# Altium schematic sheet Microphone.SchDoc
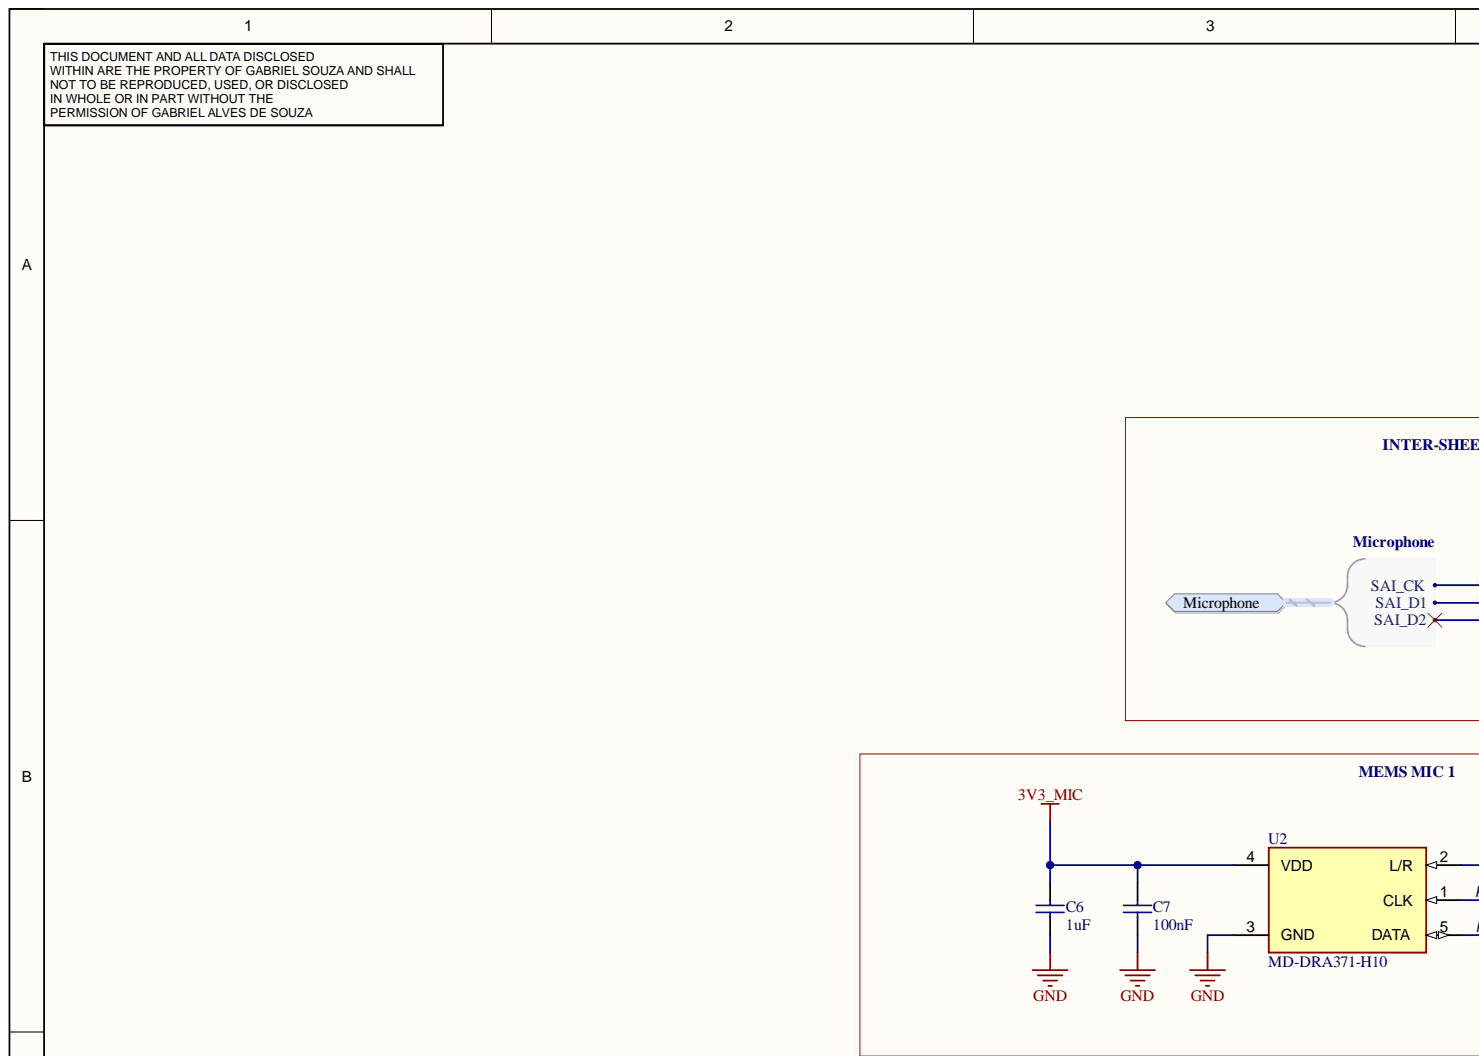
[diagram p0: Microphone.SchDoc, top left]
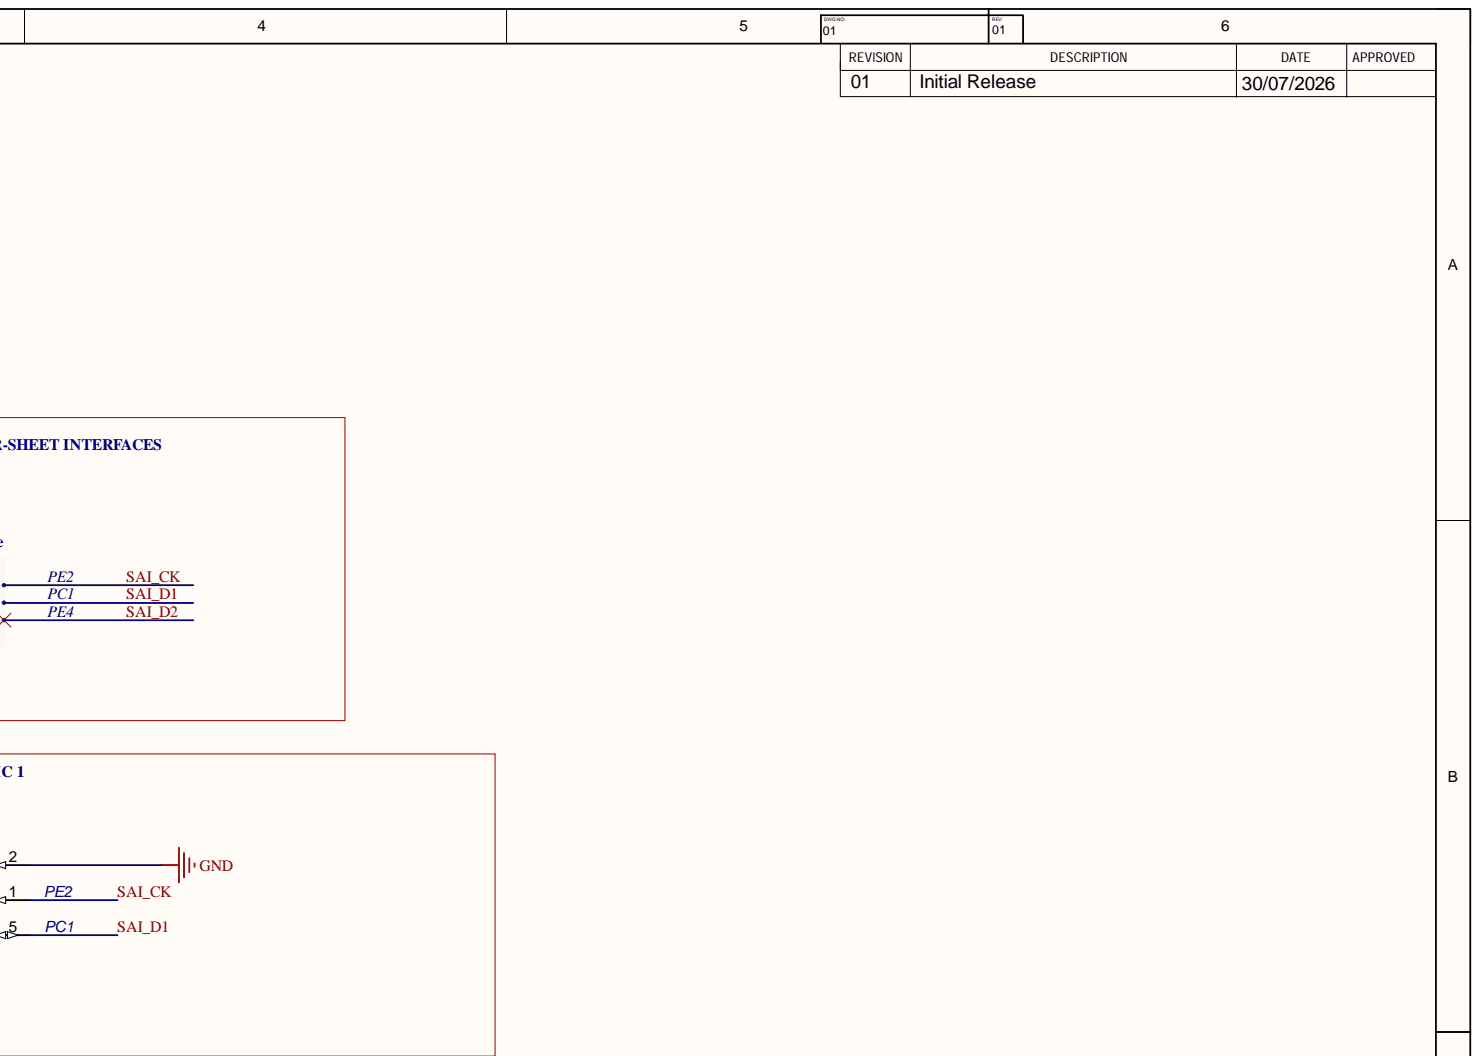
[diagram p1: Microphone.SchDoc, top right]
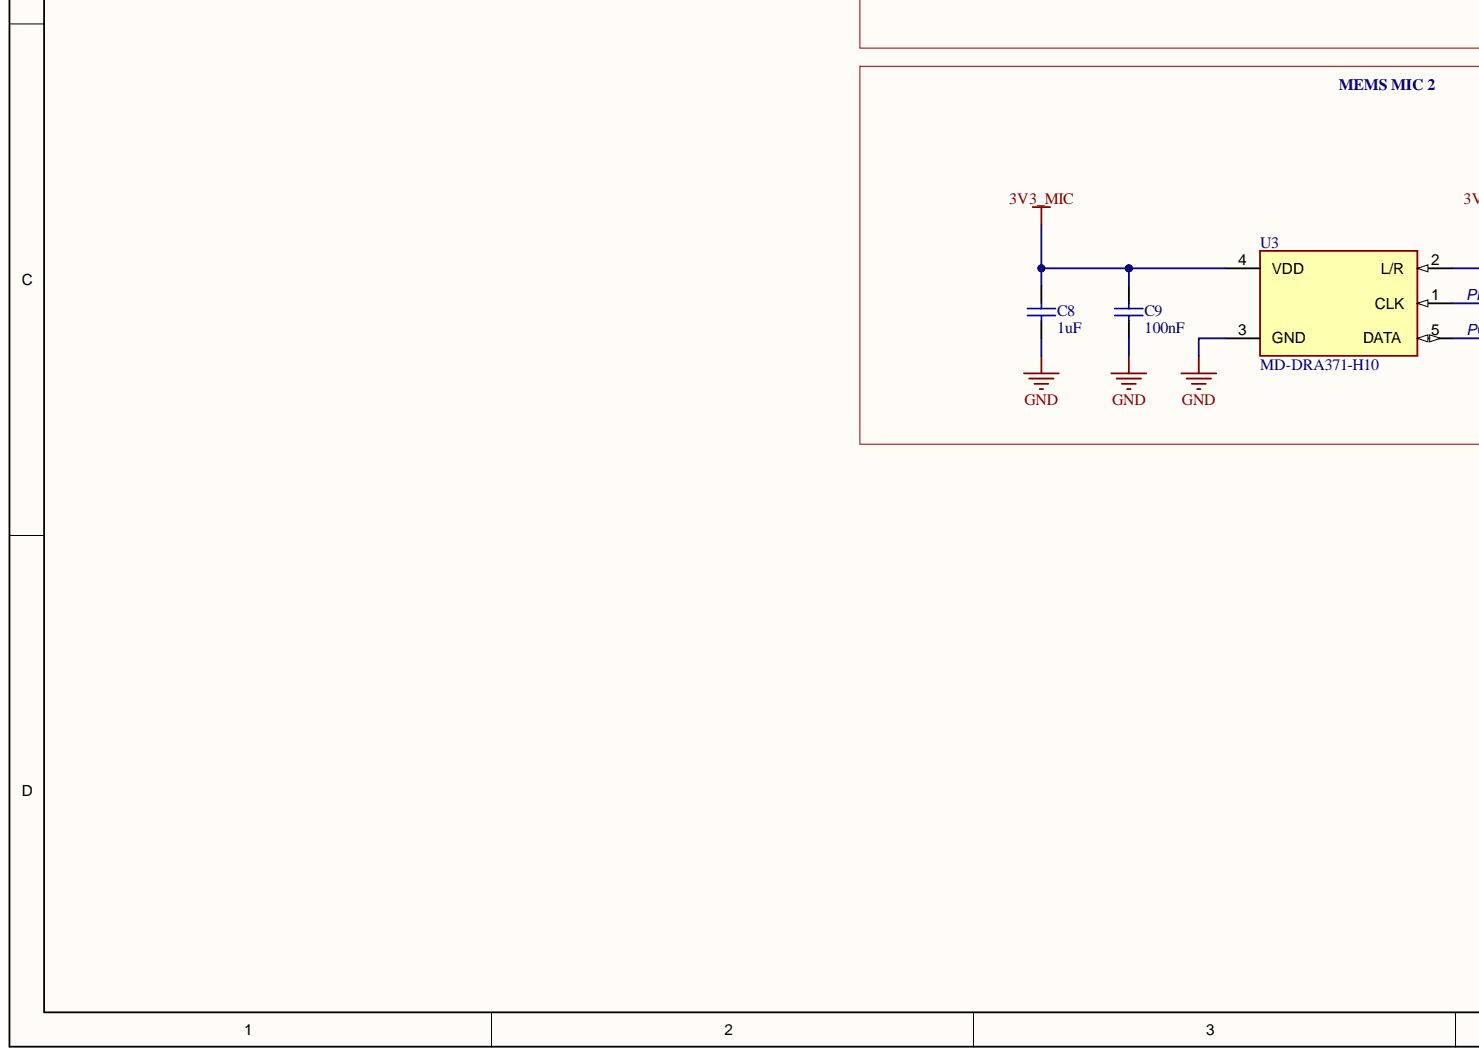
[diagram p2: Microphone.SchDoc, bottom left]
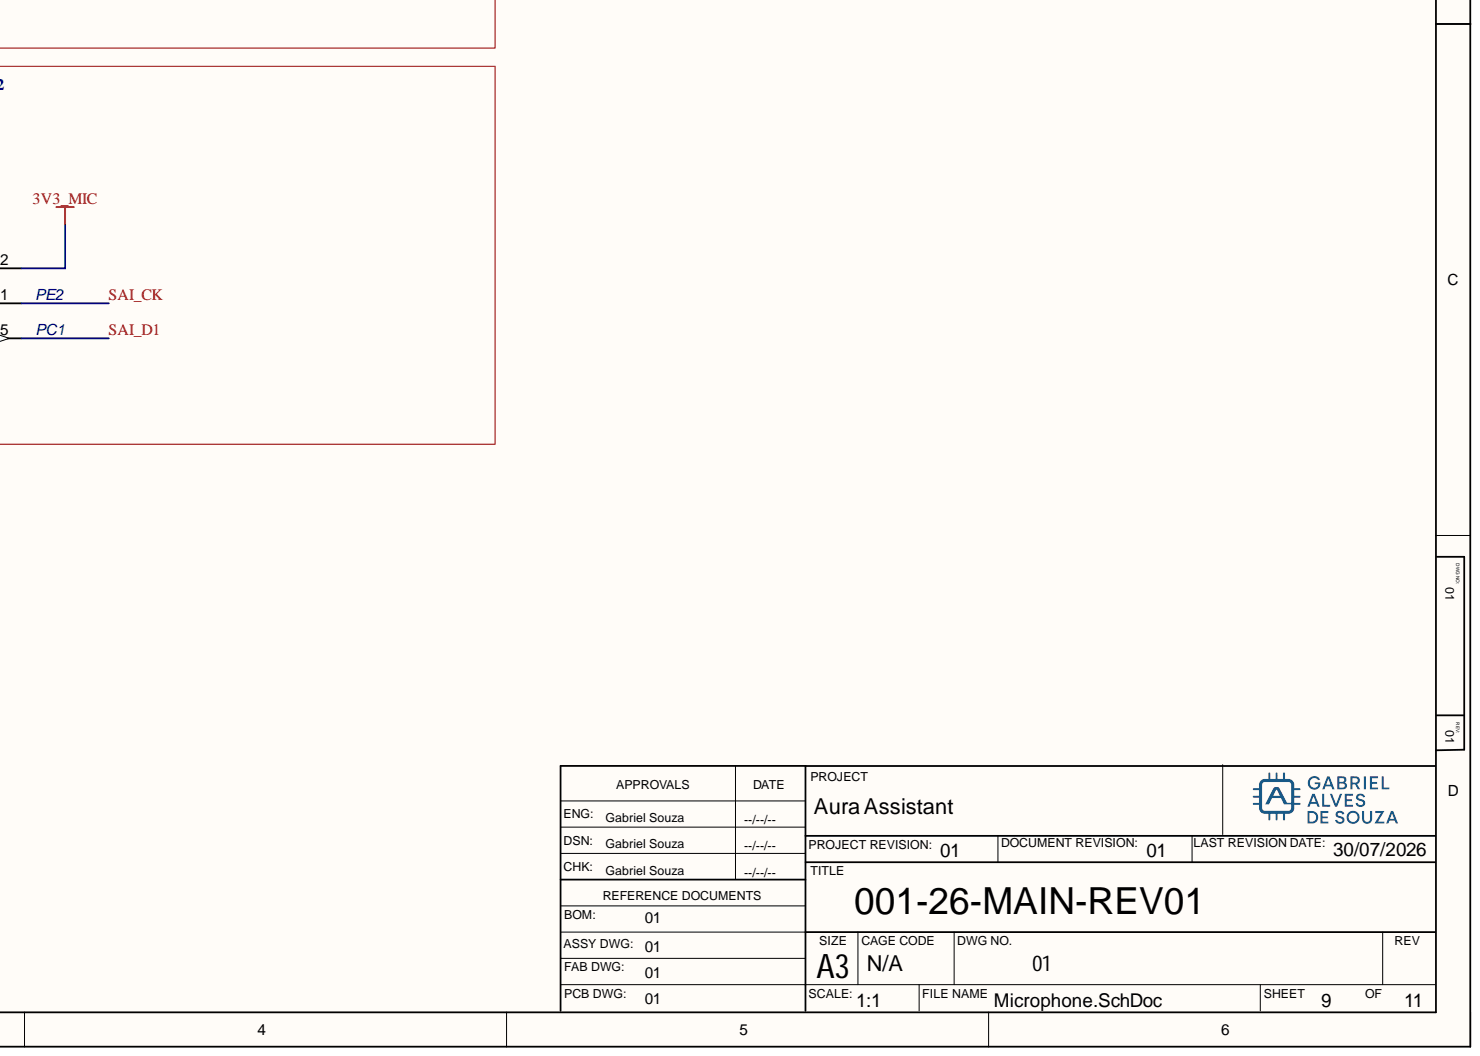
[diagram p3: Microphone.SchDoc, bottom right]

## Parts
C6  value 1uF  [C_0402_IPC_N]  (hidden comment: Capacitor)
C7  value 100nF  [C_0402_IPC_N]  (hidden comment: Capacitor)
C8  value 1uF  [C_0402_IPC_N]  (hidden comment: Capacitor)
C9  value 100nF  [C_0402_IPC_N]  (hidden comment: Capacitor)
U2  MD-DRA371-H10  [FP-PG-LLGA-5-MFG]
U3  MD-DRA371-H10  [FP-PG-LLGA-5-MFG]

## Ports
Microphone, Microphone.SAI_CK, Microphone.SAI_D1, Microphone.SAI_D2

## Nets
3V3_MIC: C6.2 C7.2 C8.2 C9.2 U2.4(VDD) U3.2(L/R) U3.4(VDD)
GND: C6.1 C7.1 C8.1 C9.1 U2.2(L/R) U2.3(GND) U3.3(GND)
SAI_CK: U2.1(CLK) U3.1(CLK) ; port Microphone
SAI_D1: U2.5(DATA) U3.5(DATA) ; port Microphone
SAI_D2: ; port Microphone

## Library data of the parts
C6 C8 = CAP: Capacitor, MLCC, 1uF, 10V, X5R, +/-10%, 0402, T=0,5mm; Manufacturer: FOJAN; Manufacturer_Part_Number: FCC0402X105K100AT; Component Kind: Standard; ComponentLink1Description: Datasheet; ComponentLink1URL: https://www.lcsc.com/datasheet/C5137473.pdf?spm=wm.sxq.inf.ggs___wm.fly.bg.0.xh&lcsc_vid=TlIKVlcFRVJWVl1WQVFfBlQDRFgPAVVQRFhaBQIFFgQxVlNeRVRbU1RQQ1dWUTtW; Order_Code_LCSC: C5137473; Package: 0402; Library Name: Gabriel_Lib.IntLib
C7 C9 = CAP: Capacitor, MLCC, 100nF, 16V, X7R, +/-10%, 0402, T=0,5mm; Manufacturer: TDK; Manufacturer_Part_Number: C1005X7R1C104KT000F; Component Kind: Standard; ComponentLink1Description: Datasheet; ComponentLink1URL: https://www.lcsc.com/datasheet/C338032.pdf?spm=wm.sxq.inf.ggs___wm.fly.bg.6.xh&lcsc_vid=TlIKVlcFRVJWVl1WQVFfBlQDRFgPAVVQRFhaBQIFFgQxVlNeRVRbU1RQQ1dWUTtW; Order_Code_LCSC: C338032; Package: 0402; Library Name: Gabriel_Lib.IntLib
U2 U3 = CMP-32873-000001-1: Omnidirectional -37dB 70dB SMD Microphones RoHS; Manufacturer: Gettop; Max Supply Voltage: 3.6V; Component Kind: Standard; ComponentLink2Description: Datasheet; ComponentLink2URL: https://www.lcsc.com/datasheet/C50275760.pdf?spm=wm.sxq.inf.ggs___wm.fly.bg.22.xh&lcsc_vid=TlIKVlcFRVJWVl1WQVFfBlQDRFgPAVVQRFhaBQIFFgQxVlNeRVdcXlVSRFJbUTsOAxUeFF5JWBYZEEoKFBINSQcJGk4NBhADEA4cHktTQ08GEwkK; Library Name: Altium Content Vault; Manufacturer Part Number: MD-DRA371-H10; Order_Code_LCSC: C50275760; Package: PG-LLGA-5
